# Altium schematic sheet ProtoController.SchDoc
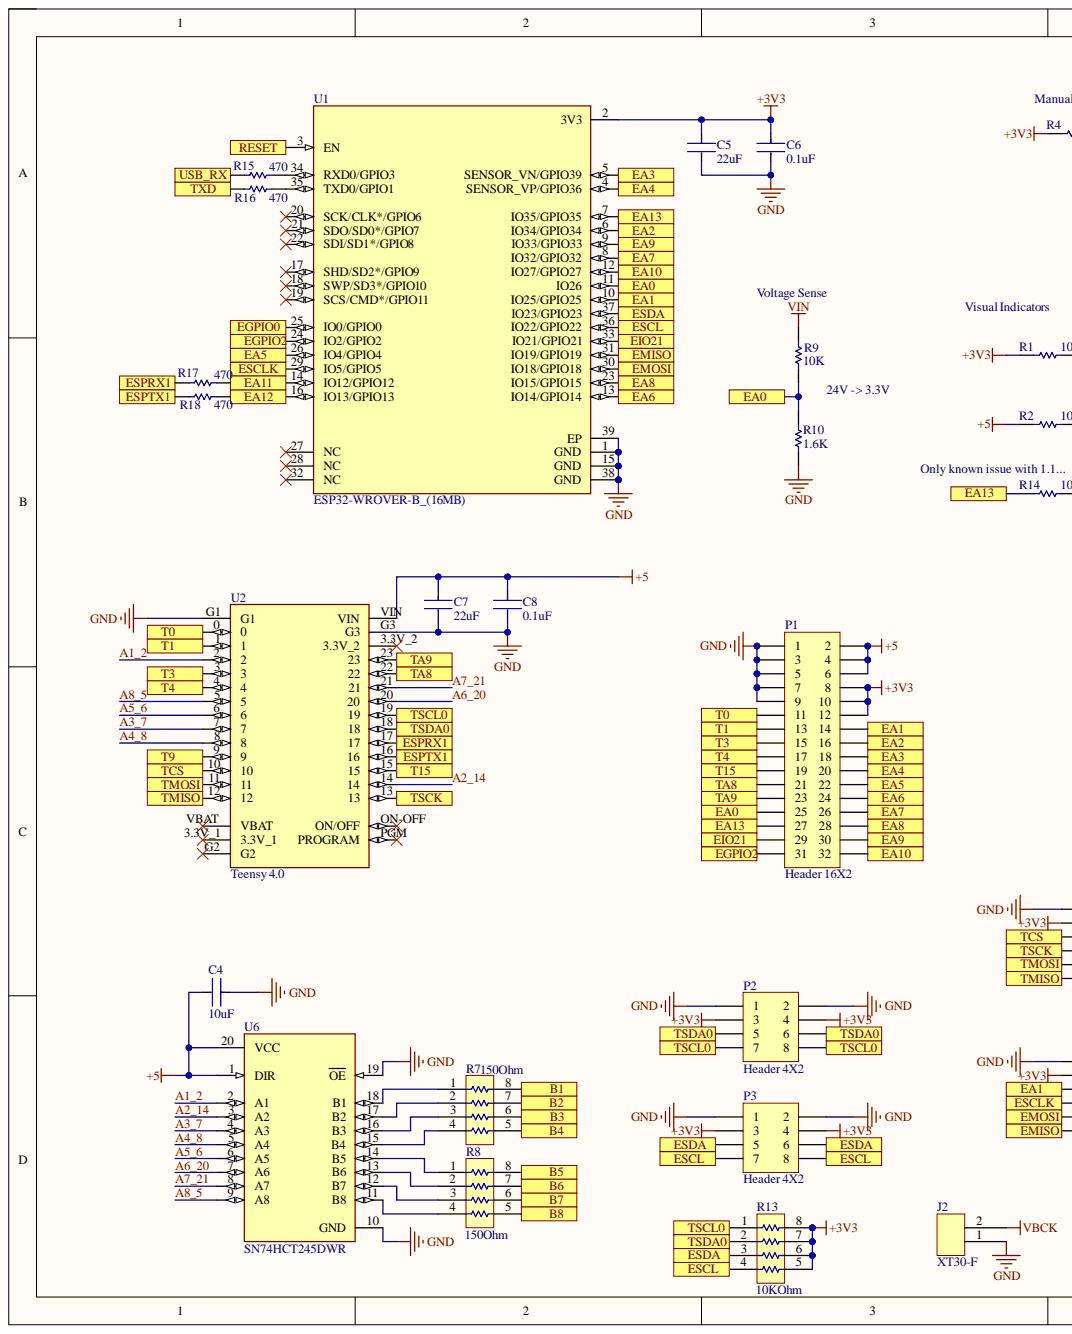
[diagram p0: ProtoController.SchDoc, left]
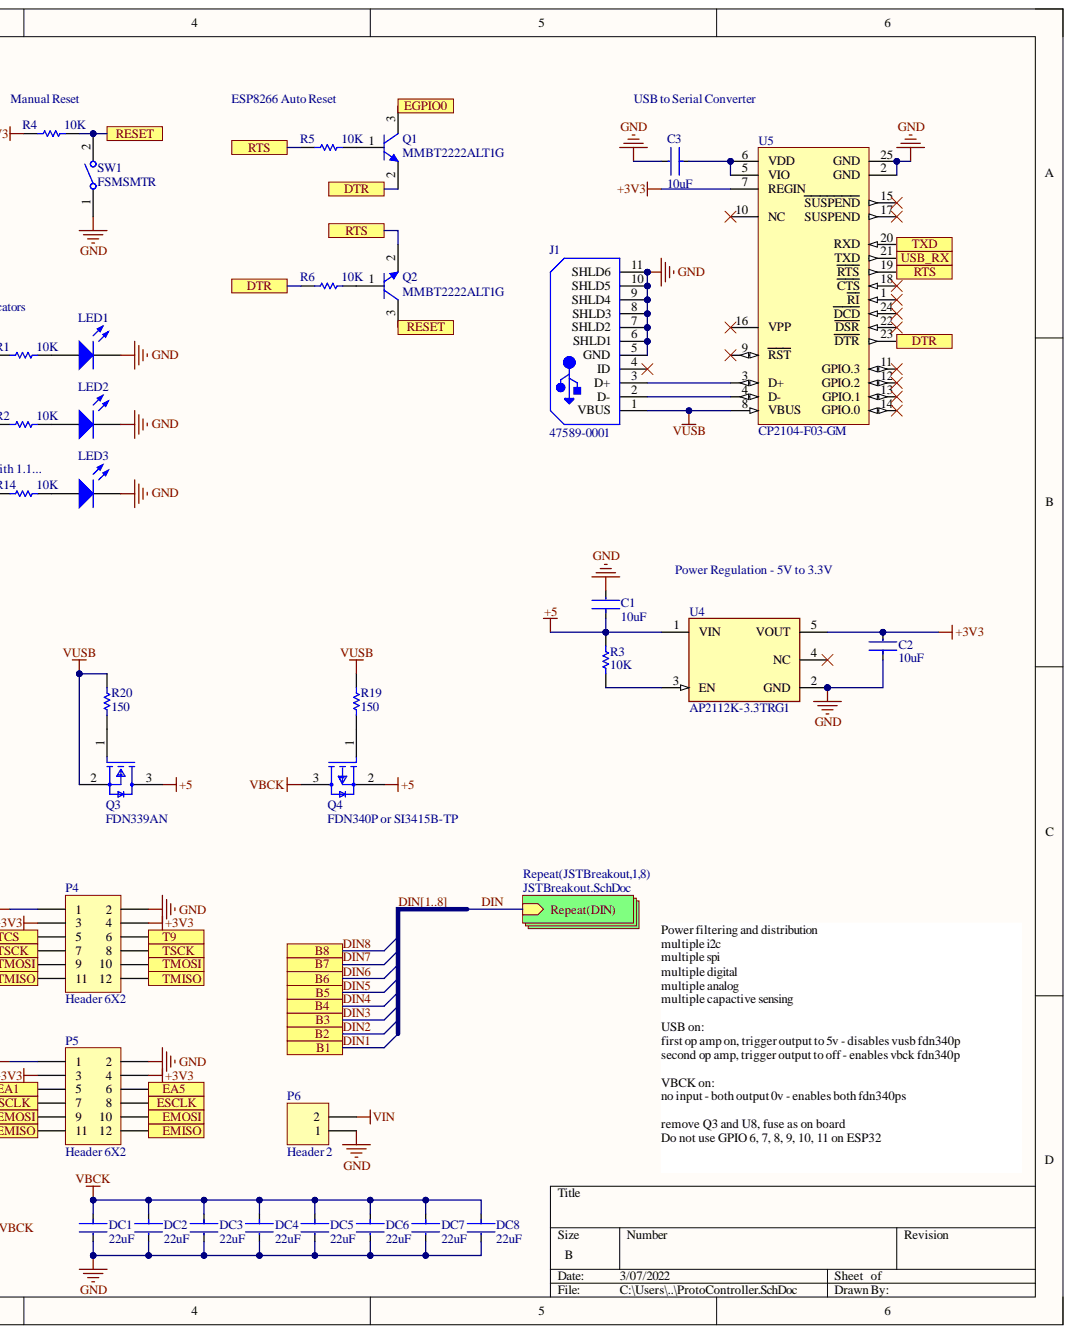
[diagram p1: ProtoController.SchDoc, right]

## Parts
C1  value 10uF  [FP-CC0805-0_85-MFG]  (hidden comment: CC0805KKX7R6BB105)
C2  value 10uF  [FP-CC0805-0_85-MFG]  (hidden comment: CC0805KKX7R6BB105)
C3  value 10uF  [FP-CC0805-0_85-MFG]  (hidden comment: CC0805KKX7R6BB105)
C4  value 10uF  [FP-CC0805-0_85-MFG]  (hidden comment: CC0805KKX7R6BB105)
C5  value 22uF  [FP-CC0805-0_85-MFG]  (hidden comment: CC0805KKX7R6BB105)
C6  value 0.1uF  [FP-CC0805-0_85-MFG]  (hidden comment: CC0805KKX7R6BB105)
C7  value 22uF  [FP-CC0805-0_85-MFG]  (hidden comment: CC0805KKX7R6BB105)
C8  value 0.1uF  [FP-CC0805-0_85-MFG]  (hidden comment: CC0805KKX7R6BB105)
DC1  value 22uF  [FP-CC0805-0_85-MFG]  (hidden comment: CC0805KKX7R6BB105)
DC2  value 22uF  [FP-CC0805-0_85-MFG]  (hidden comment: CC0805KKX7R6BB105)
DC3  value 22uF  [FP-CC0805-0_85-MFG]  (hidden comment: CC0805KKX7R6BB105)
DC4  value 22uF  [FP-CC0805-0_85-MFG]  (hidden comment: CC0805KKX7R6BB105)
DC5  value 22uF  [FP-CC0805-0_85-MFG]  (hidden comment: CC0805KKX7R6BB105)
DC6  value 22uF  [FP-CC0805-0_85-MFG]  (hidden comment: CC0805KKX7R6BB105)
DC7  value 22uF  [FP-CC0805-0_85-MFG]  (hidden comment: CC0805KKX7R6BB105)
DC8  value 22uF  [FP-CC0805-0_85-MFG]  (hidden comment: CC0805KKX7R6BB105)
J1  47589-0001  [MOLX-47589-0001_V]
J2  XT30-F  [XT30-F-Footprint-1]
LED1  150080SS75000  [0805_A]  (value not drawn)
LED2  150080SS75000  [0805_A]  (value not drawn)
LED3  150080SS75000  [0805_A]  (value not drawn)
P1  Header 16X2  [HDR2X16]
P2  Header 4X2  [HDR2X4]
P3  Header 4X2  [HDR2X4]
P4  Header 6X2  [HDR2X6]
P5  Header 6X2  [HDR2X6]
P6  Header 2  [HDR1X2]
Q1  MMBT2222ALT1G  [ONSC-SOT-23-3-318-08_V]
Q2  MMBT2222ALT1G  [ONSC-SOT-23-3-318-08_V]
Q3  FDN339AN  [FP-527AG-MFG]
Q4  FDN340P or SI3415B-TP  [FP-527AG-MFG]
R1  value 10K  [RESC2013X50X30LL20T20]  (hidden comment: CRCW08050000Z0EA)
R2  value 10K  [RESC2013X50X30LL20T20]  (hidden comment: CRCW08050000Z0EA)
R3  value 10K  [RESC2013X50X30LL20T20]  (hidden comment: CRCW08050000Z0EA)
R4  value 10K  [RESC2013X50X30LL20T20]  (hidden comment: CRCW08050000Z0EA)
R5  value 10K  [RESC2013X50X30LL20T20]  (hidden comment: CRCW08050000Z0EA)
R6  value 10K  [RESC2013X50X30LL20T20]  (hidden comment: CRCW08050000Z0EA)
R7  150Ohm  [BOUR-CAT16-103J4LF_L]
R8  150Ohm  [BOUR-CAT16-103J4LF_L]
R9  value 10K  [RESC2013X50X30LL20T20]  (hidden comment: CRCW08050000Z0EA)
R10  value 1.6K  [RESC2013X50X30LL20T20]  (hidden comment: CRCW08050000Z0EA)
R13  10KOhm  [BOUR-CAT16-103J4LF_L]
R14  value 10K  [RESC2013X50X30LL20T20]  (hidden comment: CRCW08050000Z0EA)
R15  value 470  [RESC2013X50X30LL20T20]  (hidden comment: CRCW08050000Z0EA)
R16  value 470  [RESC2013X50X30LL20T20]  (hidden comment: CRCW08050000Z0EA)
R17  value 470  [RESC2013X50X30LL20T20]  (hidden comment: CRCW08050000Z0EA)
R18  value 470  [RESC2013X50X30LL20T20]  (hidden comment: CRCW08050000Z0EA)
R19  value 150  [RESC2013X50X30LL20T20]  (hidden comment: CRCW08050000Z0EA)
R20  value 150  [RESC2013X50X30LL20T20]  (hidden comment: CRCW08050000Z0EA)
SW1  FSMSMTR  [TECO-FSMSMTR_V]
U1  ESP32-WROVER-B_(16MB)  [XCVR_ESP32-WROVER-B_(16MB)]
U2  Teensy 4.0  [MODULE_DEV-15583]
U4  AP2112K-3.3TRG1  [FP-SOT25-IPC_A]
U5  CP2104-F03-GM  [SLAB-QFN-24-2675x2675TP_M]
U6  SN74HCT245DWR  [FP-DW0020A-MFG]

## Ports
B1, B2, B3, B4, B5, B6, B7, B8, DTR, EA0, EA1, EA2, EA3, EA4, EA5, EA6, EA7, EA8, EA9, EA10, EA11, EA12, EA13, EGPIO0, EGPIO2, EIO21, EMISO, EMOSI, ESCL, ESCLK, ESDA, ESPRX1, ESPTX1, RESET, RTS, T0, T1, T3, T4, T9, T15, TA8, TA9, TCS, TMISO, TMOSI, TSCK, TSCL0, TSDA0, TXD, USB_RX

## Nets
+3V3: C2.2 C5.1 C6.1 P1.8 P1.10 P1.12 P2.3 P2.4 P3.3 P3.4 P4.3 P4.4 P5.3 P5.4 R1.1 R4.1 R13.5 R13.6 R13.7 R13.8 U1.2(3V3) U4.5(VOUT) U5.7(REGIN)
+5: C1.1 C4.2 C7.1 C8.1 P1.2 P1.4 P1.6 Q3.3 Q4.2 R2.1 R3.2 U2.VIN U4.1(VIN) U6.1(DIR) U6.20(VCC)
GND: C1.2 C2.1 C3.2 C4.1 C5.2 C6.2 C7.2 C8.2 DC1.2 DC2.2 DC3.2 DC4.2 DC5.2 DC6.2 DC7.2 DC8.2 J1.5(GND) J1.6(SHLD1) J1.7(SHLD2) J1.8(SHLD3) J1.9(SHLD4) J1.10(SHLD5) J1.11(SHLD6) J2.1 LED1.1 LED2.1 LED3.1 P1.1 P1.3 P1.5 P1.7 P1.9 P2.1 P2.2 P3.1 P3.2 P4.1 P4.2 P5.1 P5.2 P6.1 R10.2 SW1.1 U1.1(GND) U1.15(GND) U1.38(GND) U1.39(EP) U2.G1 U2.G3 U4.2(GND) U5.2(GND) U5.25(GND) U6.10(GND) U6.19(OE)
VBCK: DC1.1 DC2.1 DC3.1 DC4.1 DC5.1 DC6.1 DC7.1 DC8.1 J2.2 Q4.3
VIN: P6.2 R9.1
VUSB: J1.1(VBUS) Q3.2 R19.2 R20.2 U5.8(VBUS)
A1_2: U2.2 U6.2(A1)
A2_14: U2.14 U6.3(A2)
A3_7: U2.7 U6.4(A3)
A4_8: U2.8 U6.5(A4)
A5_6: U2.6 U6.6(A5)
A6_20: U2.20 U6.7(A6)
A7_21: U2.21 U6.8(A7)
A8_5: U2.5 U6.9(A8)
DIN1: R7.8 ; port B1  [also labelled DIN]
DIN2: R7.7 ; port B2  [also labelled DIN]
DIN3: R7.6 ; port B3  [also labelled DIN]
DIN4: R7.5 ; port B4  [also labelled DIN]
DIN5: R8.8 ; port B5  [also labelled DIN]
DIN6: R8.7 ; port B6  [also labelled DIN]
DIN7: R8.6 ; port B7  [also labelled DIN]
DIN8: R8.5 ; port B8  [also labelled DIN]
DTR: Q1.2 R6.1 U5.23(DTR) ; port DTR
EA0: P1.25 R9.2 R10.1 U1.11(IO26) ; port EA0
EA1: P1.14 P5.5 U1.10(IO25/GPIO25) ; port EA1
EA2: P1.16 U1.6(IO34/GPIO34) ; port EA2
EA3: P1.18 U1.5(SENSOR_VN/GPIO39) ; port EA3
EA4: P1.20 U1.4(SENSOR_VP/GPIO36) ; port EA4
EA5: P1.22 P5.6 U1.26(IO4/GPIO4) ; port EA5
EA6: P1.24 U1.13(IO14/GPIO14) ; port EA6
EA7: P1.26 U1.8(IO32/GPIO32) ; port EA7
EA8: P1.28 U1.23(IO15/GPIO15) ; port EA8
EA9: P1.30 U1.9(IO33/GPIO33) ; port EA9
EA10: P1.32 U1.12(IO27/GPIO27) ; port EA10
EA11: R17.2 U1.14(IO12/GPIO12) ; port EA11
EA12: R18.2 U1.16(IO13/GPIO13) ; port EA12
EA13: P1.27 R14.1 U1.7(IO35/GPIO35) ; port EA13
EGPIO0: Q1.3 U1.25(IO0/GPIO0) ; port EGPIO0
EGPIO2: P1.31 U1.24(IO2/GPIO2) ; port EGPIO2
EIO21: P1.29 U1.33(IO21/GPIO21) ; port EIO21
EMISO: P5.11 P5.12 U1.31(IO19/GPIO19) ; port EMISO
EMOSI: P5.9 P5.10 U1.30(IO18/GPIO18) ; port EMOSI
ESCL: P3.7 P3.8 R13.4 U1.36(IO22/GPIO22) ; port ESCL
ESCLK: P5.7 P5.8 U1.29(IO5/GPIO5) ; port ESCLK
ESDA: P3.5 P3.6 R13.3 U1.37(IO23/GPIO23) ; port ESDA
ESPRX1: R17.1 U2.17 ; port ESPRX1
ESPTX1: R18.1 U2.16 ; port ESPTX1
RESET: Q2.3 R4.2 SW1.2 U1.3(EN) ; port RESET
RTS: Q2.2 R5.1 U5.19(RTS) ; port RTS
T0: P1.11 U2.0 ; port T0
T1: P1.13 U2.1 ; port T1
T3: P1.15 U2.3 ; port T3
T4: P1.17 U2.4 ; port T4
T9: P4.6 U2.9 ; port T9
T15: P1.19 U2.15 ; port T15
TA8: P1.21 U2.22 ; port TA8
TA9: P1.23 U2.23 ; port TA9
TCS: P4.5 U2.10 ; port TCS
TMISO: P4.11 P4.12 U2.12 ; port TMISO
TMOSI: P4.9 P4.10 U2.11 ; port TMOSI
TSCK: P4.7 P4.8 U2.13 ; port TSCK
TSCL0: P2.7 P2.8 R13.1 U2.19 ; port TSCL0
TSDA0: P2.5 P2.6 R13.2 U2.18 ; port TSDA0
TXD: R16.1 U5.20(RXD) ; port TXD
USB_RX: R15.1 U5.21(TXD) ; port USB_RX
NetC3_1: C3.1 U5.5(VIO) U5.6(VDD)
NetJ1_2: J1.2(D-) U5.4(D-)
NetJ1_3: J1.3(D+) U5.3(D+)
NetLED1_2: LED1.2 R1.2
NetLED2_2: LED2.2 R2.2
NetLED3_2: LED3.2 R14.2
NetQ1_1: Q1.1 R5.2
NetQ2_1: Q2.1 R6.2
NetQ3_1: Q3.1 R20.1
NetQ4_1: Q4.1 R19.1
NetR3_1: R3.1 U4.3(EN)
NetR7_1: R7.1 U6.18(B1)
NetR7_2: R7.2 U6.17(B2)
NetR7_3: R7.3 U6.16(B3)
NetR7_4: R7.4 U6.15(B4)
NetR8_1: R8.1 U6.14(B5)
NetR8_2: R8.2 U6.13(B6)
NetR8_3: R8.3 U6.12(B7)
NetR8_4: R8.4 U6.11(B8)
NetR15_2: R15.2 U1.34(RXD0/GPIO3)
NetR16_2: R16.2 U1.35(TXD0/GPIO1)

Not connected: J1 4(ID); U1 17(SHD/SD2*/GPIO9) 18(SWP/SD3*/GPIO10) 19(SCS/CMD*/GPIO11) 20(SCK/CLK*/GPIO6) 21(SDO/SD0*/GPIO7) 22(SDI/SD1*/GPIO8) 27(NC) 28(NC) 32(NC); U2 3.3V_1 3.3V_2 G2 ON-OFF(ON/OFF) PGM(PROGRAM) VBAT; U4 4(NC); U5 1(RI) 9(RST) 10(NC) 11(GPIO.3) 12(GPIO.2) 13(GPIO.1) 14(GPIO.0) 15(SUSPEND) 16(VPP) 17(SUSPEND) 18(CTS) 22(DSR) 24(DCD)

## Library data of the parts
C1-C8 = CMP-03422-000182-1: CAP CER 1UF 10V X7R 0805; Manufacturer: Yageo; Material: Ceramic; Tolerance: 0.1; Automotive: No; Capacitance: 1 uF; Category: Cap; Component Kind: Standard; ComponentLink2Description: Datasheet; ComponentLink2URL: http://www.yageo.com/documents/recent/UPY-GPHC_Y5V_6.3V-to-50V_8.pdf; Device Class L1: Passive Components; Device Class L2: Capacitors; Device Class L3: Ceramic Capacitors; Digikey Description: CAP CER 1UF 10V X7R 0805; Footprint Url: http://www.yageo.com/exep/pages/download/literatures/UPY-C_GEN_24.pdf; Height: 0.95mm; Ipc Land Pattern Name: CAPC200125X85; Lead Free: Yes; Library Name: Altium Content Vault; Manufacturer Part Number: CC0805KKX7R6BB105; Mouser Description: Multilayer Ceramic Capacitors MLCC - SMD/SMT 1.0uF 10V X7R 10%; Octopart: https://octopart.com/cc0805kkx7r6bb105-yageo-42522859; Package: CC0805-0.85; RoHS: TRUE; Temperature: -30°C to +85°C; Temperature Characteristic: X7R; Temperature Range High: +85°C; Temperature Range Low: -30°C; Voltage Rating: 10 V
DC1-DC8 = CMP-03422-000182-1: CAP CER 1UF 10V X7R 0805; Manufacturer: Yageo; Tolerance: 0.1; Automotive: No; Capacitance: 1 uF; Category: Cap; Component Kind: Standard; ComponentLink2Description: Datasheet; ComponentLink2URL: http://www.yageo.com/documents/recent/UPY-GPHC_Y5V_6.3V-to-50V_8.pdf; Device Class L1: Passive Components; Device Class L2: Capacitors; Device Class L3: Ceramic Capacitors; Digikey Description: CAP CER 1UF 10V X7R 0805; Footprint Url: http://www.yageo.com/exep/pages/download/literatures/UPY-C_GEN_24.pdf; Height: 0.95mm; Ipc Land Pattern Name: CAPC200125X85; Lead Free: Yes; Library Name: Altium Content Vault; Manufacturer Part Number: CC0805KKX7R6BB105; Material: Ceramic; Mouser Description: Multilayer Ceramic Capacitors MLCC - SMD/SMT 1.0uF 10V X7R 10%; Octopart: https://octopart.com/cc0805kkx7r6bb105-yageo-42522859; Package: CC0805-0.85; RoHS: TRUE; Temperature: -30°C to +85°C; Temperature Characteristic: X7R; Temperature Range High: +85°C; Temperature Range Low: -30°C; Voltage Rating: 10 V
J1 = CMP-2000-05610-1: Right Angle Female Type AB Micro USB Connector, 30 V, 1.8 A, -30 to 85 degC, RoHS, Tape and Reel; Brand: Molex; Connector Type: MicroUSBTypeABreceptacle; Current Rating: 1.8A; Number of Contacts: 5Contact; Number of Ports: 1Port; Voltage Rating: 30VAC; Color: Black; Component Kind: Standard; Contact Material: CopperAlloy; Contact Plating: Gold; Datasheet URL: http://www.molex.com/webdocs/datasheets/pdf/en-us/0475890001_IO_CONNECTORS.pdf; Datasheet Version: 12/2016; Gender: Female; Library Name: Altium Content Vault; Manufacturer: Molex; Manufacturer URL: http://www.molex.com/; Mounting Angle: Right; Mounting Technology: SurfaceMount; Operating Temperature Range: -20Cto+85C; Package Description: Micro USB Connector, Pitch 0.65 mm, 5 Positions, Body 7.5 x 5.6 mm; Package Reference: 47589-0001; Package URL: http://www.molex.com/pdm_docs/sd/475890001_sd.pdf; Packaging: Reel; Product: MicroUSBTypeABConnectors; Product Category: USBConnectors; RoHS: True; Series: 47589; Standard: USB; Termination Style: SMD/SMT
J2 = CMP-002-00076-4: XT30 Female connector for board mount; Component Kind: Standard; Library Name: Gannon University
LED1-LED3 = CMP-1426-00010-1: SMD mono-color Chip LED, WL-SMCW, Super Red; Manufacturer: Wuerth Elektronik; Color: Super Red; Component Kind: Standard; ComponentLink1Description: Manufacturer URL; ComponentLink1URL: http://www.we-online.com; ComponentLink2Description: Datasheet; ComponentLink2URL: http://katalog.we-online.com/led/datasheet/150080SS75000.pdf; Library Name: Altium Content Vault; PackageReference: 0805_A; PartNumber: 150080SS75000
P1 = Header 16X2: Header, 16-Pin, Dual row; Component Kind: Standard; LatestRevisionDate: 17-Jul-2002; LatestRevisionNote: Re-released for DXP Platform.; Library Name: Miscellaneous Connectors.IntLib; Signal Integrity: Connector; Publisher: Altium Limited
P2 P3 = Header 4X2: Header, 4-Pin, Dual row; Component Kind: Standard; LatestRevisionDate: 17-Jul-2002; LatestRevisionNote: Re-released for DXP Platform.; Library Name: Miscellaneous Connectors.IntLib; Signal Integrity: Connector; Publisher: Altium Limited
P4 P5 = Header 6X2: Header, 6-Pin, Dual row; Component Kind: Standard; LatestRevisionDate: 17-Jul-2002; LatestRevisionNote: Re-released for DXP Platform.; Library Name: Miscellaneous Connectors.IntLib; Signal Integrity: Connector; Publisher: Altium Limited
P6 = Header 2: Header, 2-Pin; Component Kind: Standard; LatestRevisionDate: 17-Jul-2002; LatestRevisionNote: Re-released for DXP Platform.; Library Name: Miscellaneous Connectors.IntLib; Signal Integrity: Connector; Publisher: Altium Limited
Q1 Q2 = CMP-1048-00538-1: General Purpose Transistor, NPN Silicon, 3-Pin SOT-23, Pb-Free, Tape and Reel; Manufacturer: On Semiconductor; fT Min (MHz): 300; IC Continuous (A): 0.6; Component Kind: Standard; ComponentLink1Description: Manufacturer URL; ComponentLink1URL: http://www.onsemi.com/; ComponentLink2Description: Datasheet; ComponentLink2URL: http://www.onsemi.cn/pub_link/Collateral/MMBT2222LT1-D.PDF; ComponentLink3Description: Package Specification; ComponentLink3URL: http://www.onsemi.cn/pub_link/Collateral/318-08.PDF; DatasheetVersion: Rev. 10; Library Name: Altium Content Vault; Mounting Technology: Surface Mount; PackageDescription: 3-Pin Small Outline Transistor, Body 2.92 x 1.3 mm, Pitch 0.95 mm; PackageReference: SOT-23-3-318-08; PackageVersion: Rev. AP, 11/2009; Packing: Tape and Reel; PartNumber: MMBT2222ALT1G; Polarity: NPN; RoHS: TRUE
Q3 = CMP-2000-06252-2: MOSFET N-CH 20V 3A SSOT3; Drain to Source Resistance: 29mR; Element Configuration: Single; Fall Time: 10ns; Gate to Source Voltage (Vgs): 8V; Input Capacitance: 700pF; Max Power Dissipation: 500mW; Nominal Vgs: 850mV; Number of Elements: 1; On-State Resistance: 35mR; Turn-Off Delay Time: 18ns; Turn-On Delay Time: 8ns; Case/Package: TO-236-3; Component Kind: Standard; Continuous Drain Current (ID): 3A; Current Rating: 3A; Drain to Source Breakdown Voltage: 20V; Drain to Source Voltage (Vdss): 20V; Dual Supply Voltage: 20V; Height: 0.94mm; Lead Free: Lead Free; Length: 2.92mm; Library Name: Altium Content Vault; Max Operating Temperature: 150°C; Min Operating Temperature: -55°C; Mount: Surface Mount; Number of Pins: 3; Package Quantity: 1; Packaging: Tape and Reel; Power Dissipation: 500mW; Radiation Hardening: No; Rds On Max: 35mR; REACH SVHC: No SVHC; Rise Time: 10ns; Termination: SMD/SMT; Threshold Voltage: 850mV; Voltage Rating (DC): 20V; Weight: 0.03g; Width: 1.4mm
Q4 = CMP-2100-03638-2: MOSFET P-CH 20V 2A SSOT3; Current Rating: -2A; Drain to Source Breakdown Voltage: -20V; Drain to Source Resistance: 60mR; Element Configuration: Single; Fall Time: 11ns; Gate to Source Voltage (Vgs): 8V; Input Capacitance: 779pF; Max Power Dissipation: 500mW; Nominal Vgs: -800mV; Number of Elements: 1; On-State Resistance: 70MR; Rise Time: 9ns; Turn-Off Delay Time: 27ns; Turn-On Delay Time: 10ns; Case/Package: SOT-23; Component Kind: Standard; Contact Plating: Tin; Continuous Drain Current (ID): 2A; Drain to Source Voltage (Vdss): 20V; Dual Supply Voltage: -20V; Height: 0.94mm; Lead Free: Lead Free; Length: 2.92mm; Library Name: Altium Content Vault; Max Operating Temperature: 150°C; Min Operating Temperature: -55°C; Mount: Surface Mount; Number of Pins: 3; Package Quantity: 1; Packaging: Tape and Reel; Power Dissipation: 500mW; Radiation Hardening: No; Rds On Max: 70mR; REACH SVHC: No SVHC; Termination: SMD/SMT; Threshold Voltage: -800mV; Voltage Rating (DC): -20V; Weight: 0.03g; Width: 3.05mm
R1-R6 R9 R10 R14-R20 = CMP-2001-04896-1: 0805; Manufacturer: Vishay Dale; Component Kind: Standard; Library Name: Altium Content Vault; Part Number: CRCW08050000Z0EA
R7 R8 R13 = CMP-2000-06067-1: CAT / CAY 16 Series - Chip Resistor Array, 10 KOhm, +/- 5%, 50 V, -55 to 125 degC, 8-Pin SMD, RoHS, Tape and Reel; Manufacturer: Bourns; Circuit Type: Isolated; EDA / CAD Models: DownloadfromAcceleratedDesigns; Number of Resistors: 4; Online Catalog: CAT16Series; Other Names: CAT16-103J4LFDKR; Power Per Element: 62.5mW; Resistance (Ohms): 10k; Temperature Coefficient: ±200ppm/°C; Applications: -; Category: Resistors; Component Kind: Standard; Datasheet URL: http://www.mouser.com/ds/2/54/ATCAY-777361.pdf; Datasheet Version: 09/2014; Family: ResistorNetworks,Arrays; Height: 0.024"(0.60mm); Library Name: Altium Content Vault; Manufacturer URL: http://www.bourns.com/; Mounting Technology: SurfaceMount; Mounting Type: SurfaceMount; Number of Pins: 8; Operating Temperature: -55°C~125°C; Package / Case: 1206(3216Metric),Concave,LongSideTerminals; Package Description: 8-Pin Surface Mount Device, Body 3.2 x 1.55 mm, Pitch 0.8 mm; Package Reference: CAT16-103J4LF; Packaging: Digi-Reel®; RoHS Information: CAT16MaterialDeclaration; Series: CAT16; Size / Dimension: 0.126"Lx0.061"W(3.20mmx1.55mm); Standard Package: 1; Supplier Device Package: 1206; Tolerance: ±5%
SW1 = CMP-2000-07501-1: FSMSM Push Button Switch, 50 mA, -35 to 85 degC, 2-Pin SMD, RoHS, Tape and Reel; Manufacturer: TE Connectivity; Actuator Length: 1.07mm; Actuator Material: Polyamide; Case\/Package: SMD/SMT; Contact Current Rating: 50mA; Contact Resistance: 100mOhm; Electrical Life: 20000Cycles; Illumination Type: Illuminated; Max Voltage Rating (DC): 12V; Operating Force: 180gf; Switch Travel: 0.25mm; Throw Configuration: SPST; Voltage Rating (DC): 24V; Component Kind: Standard; Contact Material: Brass; Contact Plating: Silver; Current Rating: 50mA; Datasheet URL: http://www.te.com/commerce/DocumentDelivery/DDEController?Action=showdoc&DocId=Customer+Drawing%7F1437566-3%7FC2%7Fpdf%7FEnglish%7FENG_CD_1437566-3_C2_1437566_3_1_c.dwg.pdf%7F1437566-4; Datasheet Version: Rev. C2, 08/2014; ELV: Compliant; Lead Free: LeadFree; Library Name: Altium Content Vault; Manufacturer URL: http://www.te.com/; Max Operating Temperature: 85degC; Mechanical Life: 20000Cycles; Min Operating Temperature: -35degC; Mount: SurfaceMount; Mounting Technology: SurfaceMount; Orientation: Straight; Package Description: 2-Pin Surface Mount Device, Body 6 x 3.5 mm, Pitch 9.19 mm; Package Quantity: 1800; Package Reference: FSMSMTR; Packaging: TapeandReel; REACH SVHC: Unknown; RoHSCompliant: true; Termination: GullWing
U1 = CMP-056-0000-3: Generic WiFi-BT-BLE MCU module. Flash memory of 16 MB and PSRAM of 8 MB; MF: Espressif Systems; MP: ESP32-WROVER-B _16MB_; Availability: Unavailable; Component Kind: Standard; Component Type: Standard; Design Item ID: ESP32-WROVER-B_(16MB); Package: None; Pin Count: 36; Price: None; SnapEDA_Link: https://www.snapeda.com/parts/ESP32-WROVER-B%20%2816MB%29/Espressif%20Systems/view-part/2884130/?ref=snap; Source: SnapEDAsch.SchLib; Library Name: Gannon University
U2 = CMP-023-0000-2: RT1062 Teensy 4.0 i.MX ARMÂ® CortexÂ®-M7 MPU Embedded Evaluation Board; MF: SparkFun Electronics; MP: DEV-15583; Component Kind: Standard; Component Type: Standard; Design Item ID: DEV-15583; Digi-Key_Part_Number: 1568-DEV-15583-ND; Digi-Key_Purchase_URL: https://www.digikey.com/product-detail/en/sparkfun-electronics/DEV-15583/1568-DEV-15583-ND/10384551?utm_source=snapeda&utm_medium=aggregator&utm_campaign=symbol; Package: None; Pin Count: 33; SnapEDA_Link: https://www.snapeda.com/parts/DEV-15583/SparkFun%20Electronics/view-part/4001229/?ref=snap; Source: SnapEDAsch.SchLib; Library Name: Gannon University
U4 = CMP-12756-000035-1: IC REG LINEAR 3.3V 600MA SOT25; Accuracy: 1.5%; Dropout Voltage: 250mV; Max Input Voltage: 6V; Min Input Voltage: 2.5V; Number of Outputs: 1; Output Type: Fixed; Polarity: Positive; Quiescent Current: 55uA; Case/Package: SOT-23-5; Component Kind: Standard; Height: 1.3mm; Lead Free: Lead Free; Length: 3.1mm; Library Name: Altium Content Vault; Max Operating Temperature: 85°C; Max Output Current: 600mA; Min Operating Temperature: -40°C; Mount: Surface Mount; Number of Pins: 5; Number of Regulators: 1; Output Current: 600mA; Output Voltage: 3.3V; Package Quantity: 1; Packaging: Tape and Reel; Width: 1.7mm
U5 = CMP-0511-00004-1: Single-Chip USB to UART Bridge, 4 Dig I/O Pins, Internal Oscillator, 1024 Bytes EEPROM, -40 to 85 degC, 24-pin QFN; Manufacturer: Silicon Labs; Internal Oscillator: TRUE; Sub-Family: USB Bridges; Code_IPC: J-STD-020; Code_JEDEC: MO-220; Component Kind: Standard; ComponentLink1Description: Manufacturer URL; ComponentLink1URL: http://www.silabs.com/; ComponentLink2Description: Datasheet; ComponentLink2URL: http://www.silabs.com/Support%20Documents/TechnicalDocs/cp2104.pdf; ComponentLink3Description: Package Specification; ComponentLink3URL: http://www.silabs.com/Support%20Documents/TechnicalDocs/C8051F93x-92x.pdf; DatasheetVersion: Rev. 1.0; EEPROM: 1024 Bytes; Library Name: Altium Content Vault; Mounting Technology: Surface Mount; PackageDescription: 24-Pin Quad-Flat No-Leads Package, Body 4 x 4 mm, Pitch 0.5 mm; PackageReference: QFN-24; PartNumber: CP2104-F03-GM
U6 = CMP-1620-00207-3: IC TXRX NON-INVERT 5.5V 20SOIC; Manufacturer: Texas Instruments; Frequency: 25MHz; Logic Function Desc: Non-Inverting; Max Junction Temp: +150°C; Min Supply Voltage: 4.5V; Nominal Supply Current: 80uA; Number Of Circuits: 1; Number Of Inputs: 8; Propagation Delay: 18ns; Standoff Height: 0.1mm; Ambient Temperature Range High: +85°C; Ambient Temperature Range Low: -40°C; Automotive: No; Category: IC; Component Kind: Standard; ComponentLink2Description: Datasheet; ComponentLink2URL: http://www.ti.com/lit/ds/symlink/sn74hct245.pdf; Device Class L1: Integrated Circuits (ICs); Device Class L2: Logic ICs; Device Class L3: Drivers and Transceivers; Digikey Description: IC TXRX NON-INVERT 5.5V 20SOIC; Height: 2.65mm; Ipc Land Pattern Name: SOIC127P1030X265-20; Lead Free: Yes; Library Name: Altium Content Vault; Manufacturer Part Number: SN74HCT245DWR; Max Supply Voltage: 5.5V; Mouser Description: Octal Bus Transceivers With 3-State Outputs 20-SOIC -40 to 85; Number Of Outputs: 8; Octopart: https://octopart.com/sn74hct245dwr-texas+instruments-516824; Package: DW0020A; RoHS: TRUE; Temperature: -40°C to +85°C; Temperature Range High: +85°C; Temperature Range Low: -40°C
